# Altium schematic sheet NAUT_MFC_Front.SchDoc
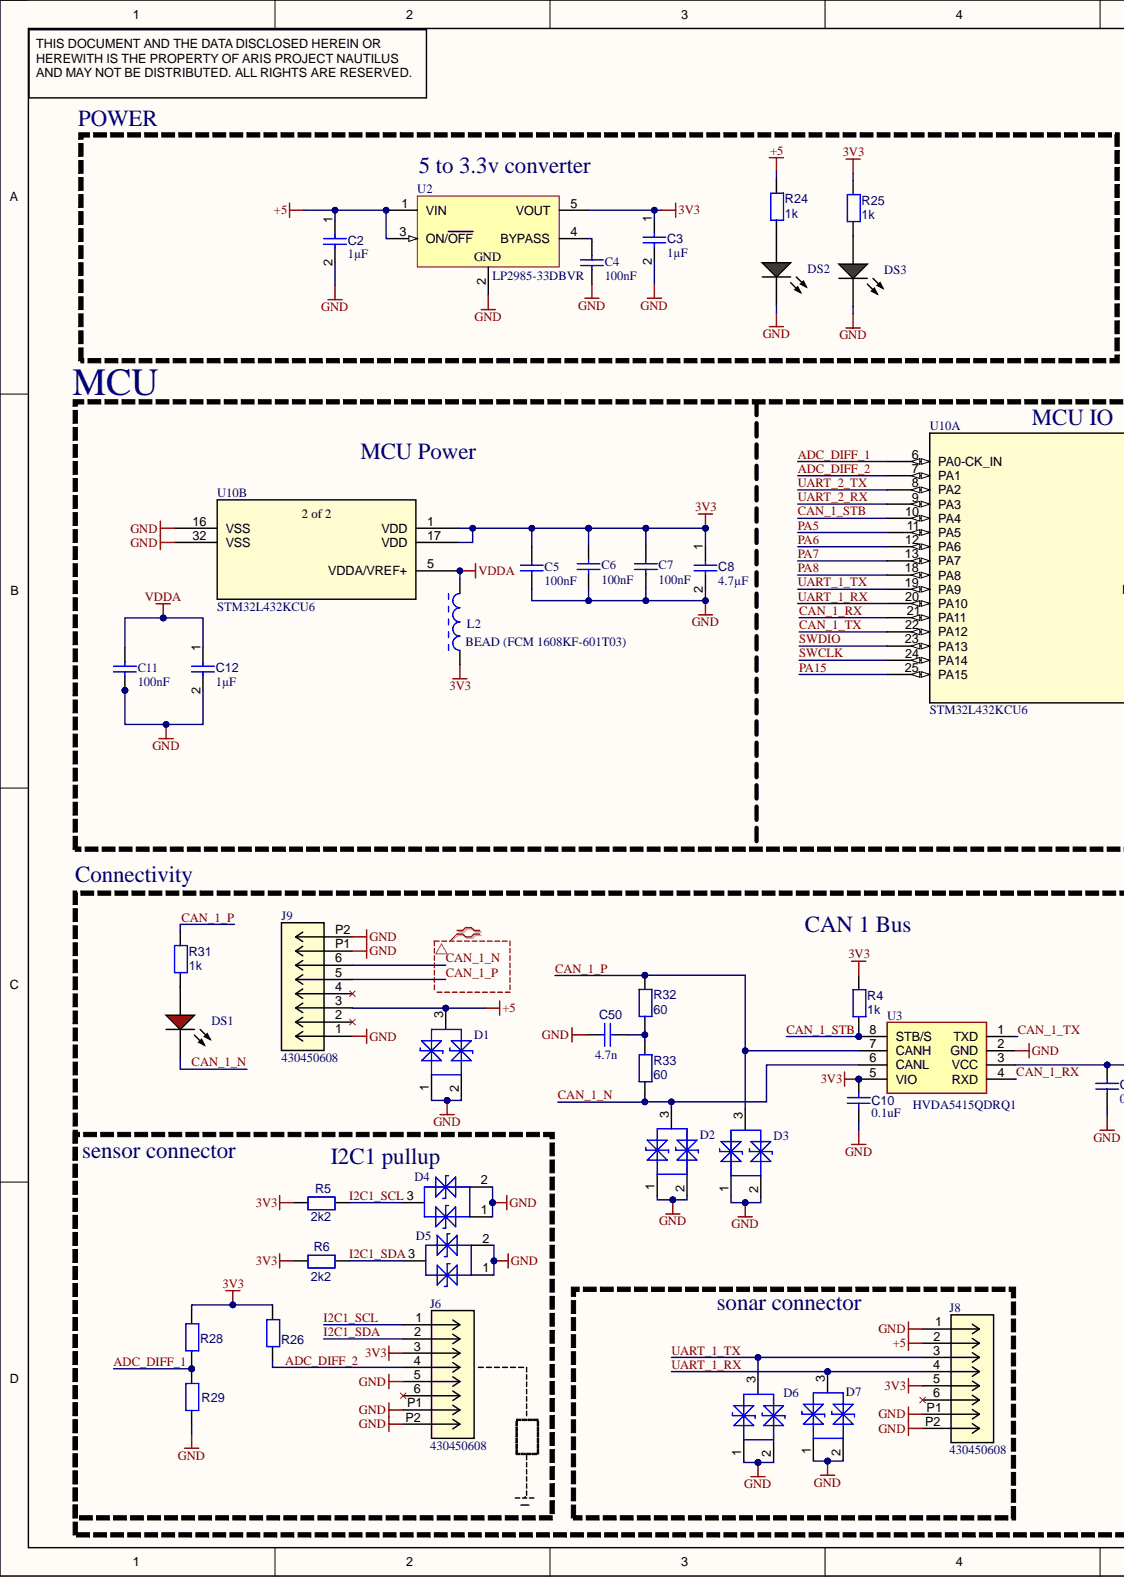
[diagram p0: NAUT_MFC_Front.SchDoc, left]
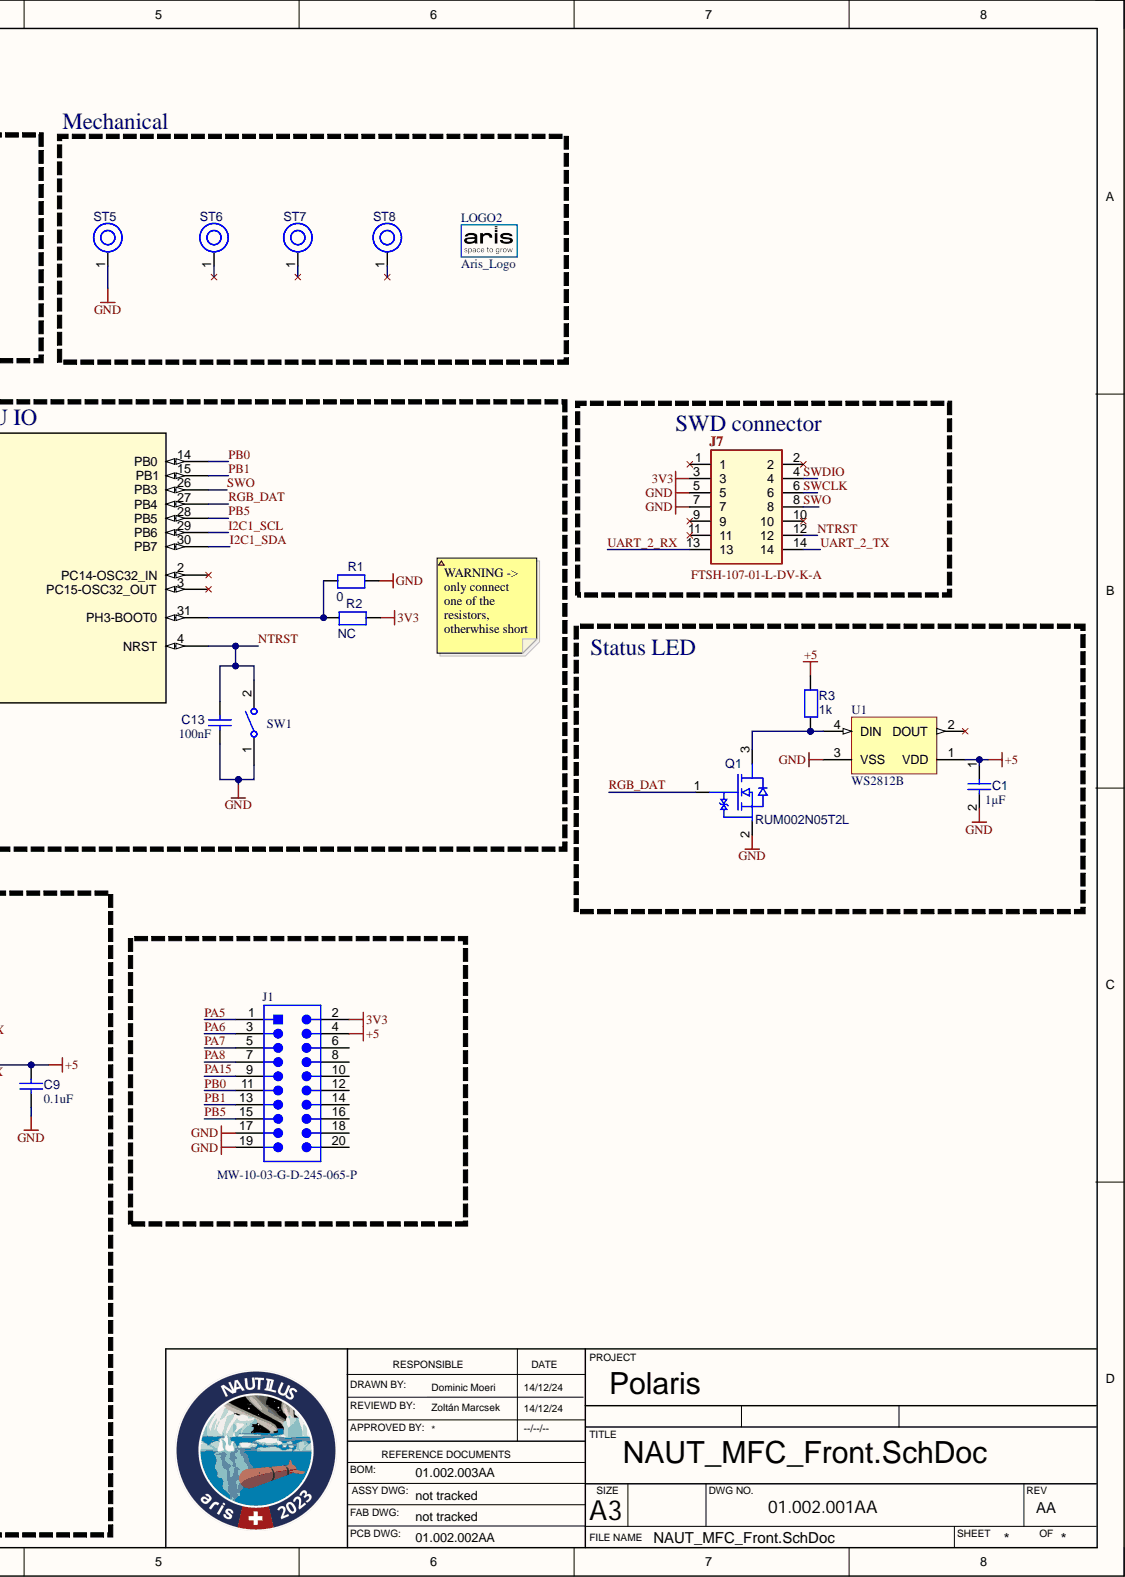
[diagram p1: NAUT_MFC_Front.SchDoc, right]

## Parts
C1  value 1µF  [CAPC1608X90X35ML15T15]  (hidden comment: Capacitor 1uF +/- 10% 16V 0603)
C2  value 1µF  [CAPC1608X90X35ML15T15]  (hidden comment: Capacitor 1uF +/- 10% 16V 0603)
C3  value 1µF  [CAPC1608X90X35ML15T15]  (hidden comment: Capacitor 1uF +/- 10% 16V 0603)
C4  value 100nF  [Capacitor 0402 ceramic]  (hidden comment: Capacitor)
C5  value 100nF  [Capacitor 0402 ceramic]  (hidden comment: Capacitor)
C6  value 100nF  [Capacitor 0402 ceramic]  (hidden comment: Capacitor)
C7  value 100nF  [Capacitor 0402 ceramic]  (hidden comment: Capacitor)
C8  value 4.7µF  [CAPC0603(1608)100_L]  (hidden comment: Capacitor 4.7µF +/-20% 10V 0603)
C9  value 0.1uF  [CAPC0603(1608)100_L]  (hidden comment: Capacitor 4.7µF +/-20% 10V 0603)
C10  value 0.1uF  [CAPC0603(1608)100_L]  (hidden comment: Capacitor 4.7µF +/-20% 10V 0603)
C11  value 100nF  [Capacitor 0402 ceramic]  (hidden comment: Capacitor)
C12  value 1µF  [CAPC0603(1608)100_L]  (hidden comment: Capacitor 1µF +/-20% 10V 0603)
C13  value 100nF  [CAPC0603(1608)100_L]  (hidden comment: Capacitor 100nF +/-20% 10V 0603)
C50  value 4.7n  [CAPC0603(1608)100_L]  (hidden comment: Capacitor 4.7µF +/-20% 10V 0603)
D1  ESD Protection diode  [SOT23]  (value not drawn)
D2  ESD Protection diode  [SOT23]  (value not drawn)
D3  ESD Protection diode  [SOT23]  (value not drawn)
D4  ESD Protection diode  [SOT23]  (value not drawn)
D5  ESD Protection diode  [SOT23]  (value not drawn)
D6  ESD Protection diode  [SOT23]  (value not drawn)
D7  ESD Protection diode  [SOT23]  (value not drawn)
DS1  LTST-C190KRKT  [LTST-C191KRKT_V]  (value not drawn)
DS2  LTST-C190GKT  [LTST-C190GKT_V]  (value not drawn)
DS3  LTST-C190GKT  [LTST-C190GKT_V]  (value not drawn)
J1  MW-10-03-G-D-245-065-P  [SMTC-MW-10-03-G-D-245-065-P_V]
J6  430450608  [Molex_microfit_CON_430450608]
J7  FTSH-107-01-L-DV-K-A  [FTSH-107-XX-YYY-DV-K-A]
J8  430450608  [Molex_microfit_CON_430450608]
J9  430450608  [Molex_microfit_CON_430450608]
L2  value BEAD (FCM 1608KF-601T03)  [INDC2013X105X50ML20T20]  (hidden comment: BLM21PG331SN1D)
LOGO2  Aris_Logo  [Aris_Logo]  (no BOM)
Q1  RUM002N05T2L  [ROHM-SOT723-3_V]
R1  0  [RESC0402(1005)_L]
R2  NC  [RESC0402(1005)_L]
R3  1k  [RESC0402(1005)_L]
R4  1k  [RESC0402(1005)_L]
R5  2k2  [RESC0402(1005)_L]
R6  2k2  [RESC0402(1005)_L]
R24  1k  [RESC0402(1005)_L]
R25  1k  [RESC0402(1005)_L]
R26  Resistor_0402_European  [RESC0402(1005)_L]  (value not drawn)
R28  Resistor_0402_European  [RESC0402(1005)_L]  (value not drawn)
R29  Resistor_0402_European  [RESC0402(1005)_L]  (value not drawn)
R31  1k  [RESC0402(1005)_L]
R32  60  [RESC0402(1005)_L]
R33  60  [RESC0402(1005)_L]
ST5  PTH-M2D5X5  [PTH-M2D5X5]  (value not drawn)  (no BOM)
ST6  PTH-M2D5X5  [PTH-M2D5X5]  (value not drawn)  (no BOM)
ST7  PTH-M2D5X5  [PTH-M2D5X5]  (value not drawn)  (no BOM)
ST8  PTH-M2D5X5  [PTH-M2D5X5]  (value not drawn)  (no BOM)
SW1  B3U-3000P  [OMRON-B3U-3000P_V]  (value not drawn)
U1  WS2812B  [ADAI-WS2812B_V]
U2  LP2985-33DBVR  [TI-DBV5_V]
U3  HVDA5415QDRQ1  [SOIC127P600X175-8N]
U10  STM32L432KCU6  [stm32l432kc]  (parts A,B)

## Nets
3V3: C3.1 C5.2 C6.2 C7.2 C8.1 C10.1 J1.2 J6.3 J7.3 J8.5 L2.1 R2.2 R4.2 R5.1 R6.1 R25.2 R26.2 R28.2 U2.5(VOUT) U3.5(VIO) U10.1(VDD) U10.17(VDD)
+5: C1.1 C2.1 C9.1 D1.3 J1.4 J8.2 J9.3 R3.2 R24.2 U1.1(VDD) U2.1(VIN) U2.3(ON/OFF) U3.3(VCC)
GND: C1.2 C2.2 C3.2 C4.1 C5.1 C6.1 C7.1 C8.2 C9.2 C10.2 C11.1 C12.2 C13.1 C50.2 D1.1 D1.2 D2.1 D2.2 D3.1 D3.2 D4.1 D4.2 D5.1 D5.2 D6.1 D6.2 D7.1 D7.2 DS2.2 DS3.2 J1.17 J1.19 J6.5 J6.P1 J6.P2 J7.5 J7.7 J8.1 J8.P1 J8.P2 J9.1 J9.P1 J9.P2 Q1.2 R1.2 R29.1 ST5.1 SW1.1 U1.3(VSS) U2.2 U3.2(GND) U10.16(VSS) U10.32(VSS)
VDDA: C11.2 C12.1 L2.2 U10.5(VDDA/VREF+)
ADC_DIFF_1: R28.1 R29.2 U10.6(PA0-CK_IN)
ADC_DIFF_2: J6.4 R26.1 U10.7(PA1)
CAN_1_N: D2.3 DS1.2 J9.6 R33.1 U3.6(CANL)
CAN_1_P: D3.3 J9.5 R31.2 R32.2 U3.7(CANH)
CAN_1_RX: U3.4(RXD) U10.21(PA11)
CAN_1_STB: R4.1 U3.8(STB/S) U10.10(PA4)
CAN_1_TX: U3.1(TXD) U10.22(PA12)
I2C1_SCL: D4.3 J6.1 R5.2 U10.29(PB6)
I2C1_SDA: D5.3 J6.2 R6.2 U10.30(PB7)
NTRST: C13.2 J7.12 SW1.2 U10.4(NRST)
PA5: J1.1 U10.11(PA5)
PA6: J1.3 U10.12(PA6)
PA7: J1.5 U10.13(PA7)
PA8: J1.7 U10.18(PA8)
PA15: J1.9 U10.25(PA15)
PB0: J1.11 U10.14(PB0)
PB1: J1.13 U10.15(PB1)
PB5: J1.15 U10.28(PB5)
RGB_DAT: Q1.1 U10.27(PB4)
SWCLK: J7.6 U10.24(PA14)
SWDIO: J7.4 U10.23(PA13)
SWO: J7.8 U10.26(PB3)
UART_1_RX: D7.3 J8.4 U10.20(PA10)
UART_1_TX: D6.3 J8.3 U10.19(PA9)
UART_2_RX: J7.13 U10.9(PA3)
UART_2_TX: J7.14 U10.8(PA2)
NetC4_2: C4.2 U2.4(BYPASS)
NetC50_1: C50.1 R32.1 R33.2
NetDS1_1: DS1.1 R31.1
NetDS2_1: DS2.1 R24.1
NetDS3_1: DS3.1 R25.1
NetQ1_3: Q1.3 R3.1 U1.4(DIN)
NetR1_1: R1.1 R2.1 U10.31(PH3-BOOT0)

Not connected: J1 6 8 10 12 14 16 18 20; J6 6; J7 1 2 9 10 11; J8 6; J9 2 4; ST6 1; ST7 1; ST8 1; U1 2(DOUT); U10 2(PC14-OSC32_IN) 3(PC15-OSC32_OUT)

## Library data of the parts
C1-C3 = CMP-001-00004-6: Chip Capacitor, 1 uF, +/- 10%, 16 V, 0603 (1608 Metric); Capacitor Type: Ceramic; Case/Package: 0603; Component Kind: Standard; Max Operating Temperature: 125°C; Min Operating Temperature: -55°C; Mounting Technology: SM; Pins: 2; RoHS Compliant: True; Tolerance: 10%; Voltage Rating: 16V; Library Name: Nautilus-Electronics-PCBs
C4-C7 C11 = MCD-00102: Capacitor, MLCC, 100nF, 16V, X7R, 10%, 0402, T=0,5mm; Manufacturer: YAGEO; Manufacturer_Part_Number: CC0402KRX7R7BB104; Database Table Name: MCD_PARTS$; Initial_Board_Reference: MB1262; Provided_By: Subcon; Component Kind: Standard; ComponentLink1Description: Yageo_Capacitor_X7R-series.pdf; ComponentLink1URL: http://tunmcdsvn.tun.st.com/Component_Datasheet_Library/Trunk/Capacitors/Yageo_Multilayer_Capacitor_X7R_series_100pF_to_22uF; ECCN_EU: n/a; ECCN_US: n/a; Internal_Part_Number: MCD-00102; Library Name: MCD_PARTS_database.DbLib; Package: C0402; Part_Type: Capacitor; Status: Released
C8 = CMP-001-00076-4: Chip Capacitor, 4.7µF +/-20%, 10V, 0603, Thickness 1 mm; Tolerance: 10%; Case/Package: 0603; Component Kind: Standard; Max Operating Temperature: 85°C; Min Operating Temperature: -55°C; Mounting Technology: SMT; Pins: 2; Voltage Rating: 10V; Library Name: Nautilus-Electronics-PCBs
C9 C10 C50 = CMP-001-00076-4: Tolerance: 10%; Case/Package: 0603; Component Kind: Standard; Max Operating Temperature: 85°C; Min Operating Temperature: -55°C; Mounting Technology: SMT; Pins: 2; Voltage Rating: 10V; Library Name: Nautilus-Electronics-PCBs
C12 = CMP-001-00076-4: Chip Capacitor, 1µF +/-20%, 10V, 0603, Thickness 1 mm; Tolerance: 10%; Case/Package: 0603; Component Kind: Standard; Max Operating Temperature: 85°C; Min Operating Temperature: -55°C; Mounting Technology: SMT; Pins: 2; Voltage Rating: 10V; Library Name: Nautilus-Electronics-PCBs
C13 = CMP-001-00076-4: Chip Capacitor, 100nF +/-20%, 10V, 0603, Thickness 1 mm; Tolerance: 10%; Case/Package: 0603; Component Kind: Standard; Max Operating Temperature: 85°C; Min Operating Temperature: -55°C; Mounting Technology: SMT; Pins: 2; Voltage Rating: 10V; Library Name: Nautilus-Electronics-PCBs
D1-D7 = NUP2105L: Component Kind: Standard; Library Name: NAUTILUS_COMPONENTS.SchLib
DS1 = CMP-007-00024-2: LED Uni-Color Red, 62.5 mW, 25 mA, -30 to 85 degC, 2-Pin SMD, RoHS, Tape and Reel; Manufacturer: Lite-On; Forward Current: 20mA; Forward Voltage: 2V; Lens Style: Diffused; Luminous Intensity: 54mcd; Power: 75mW; Viewing Angle: 130degrees; Case/Package: 0603; Colour: Red; Component Kind: Standard; Datasheet URL: http://optoelectronics.liteon.com/upload/download/DS-22-99-0151/S_110_LTST-C190KRKT.pdf; Datasheet Version: Rev. F, 05/2013; Illumination Colour: Red; Manufacturer URL: http://www.us.liteon.com/; Max Operating Temperature: 85°C; Min Operating Temperature: -55°C; Mounting Technology: SM; Nominal Vf: 2V; Package Description: 2-Pin Surface Mount Device, Body 1.6 x 0.8 mm; Package Quantity: 3000; Package Reference: C191KRKT; Packaging: TapeandReel; Pins: 2; RoHS Compliant: True; Library Name: Nautilus-Electronics-PCBs
DS2 DS3 = CMP-007-00022-2: LED Uni-Color Green, 100 mW, 30 mA, -55 to 85 degC, 2-Pin SMD, RoHS, Tape and Reel; Manufacturer: Lite-On; Forward Current: 20mA; Forward Voltage: 2.1V; Lens Style: Clear; Luminous Intensity: 6mcd; Power: 100mW; Viewing Angle: 130degrees; Case/Package: 0603; Colour: Green; Component Kind: Standard; Datasheet URL: http://datasheet.octopart.com/LTST-C190GKT-Lite-On-datasheet-158530.pdf; Datasheet Version: Dec-06; Illumination Colour: Green; Manufacturer URL: http://www.us.liteon.com/; Max Operating Temperature: 85°C; Min Operating Temperature: -55°C; Mounting Technology: SM; Nominal Vf: 2.1V; Package Description: 2-Pin Surface Mount Device, Body 1.6 x 0.8 mm; Package Quantity: 3000; Package Reference: C190GKT; Packaging: TapeandReel; Pins: 2; RoHS Compliant: True; Library Name: Nautilus-Electronics-PCBs
J1 = CMP-002-00046-2: Flexible Micro Board Stacker, Pitch 1 mm, 2 x 10 Position, Height 9.4 mm, -55 to 125 degC, RoHS, Tape and Reel; Manufacturer: Samtec; Connector Type: Header; Gender: Male; Case/Package: MW-10-03-G-D-245-065-P; Component Kind: Standard; Datasheet URL: http://suddendocs.samtec.com/prints/mw-xx-03-x-d-xxx-xxx-xx-mkt.pdf; Datasheet Version: 34759; Manufacturer URL: https://www.samtec.com/; Max Operating Temperature: 125°C; Min Operating Temperature: -55°C; Mounting Technology: SM; Package Description: Flexible Micro Board Stacker, Pitch 1 mm, 2 x 10 Position, Height 9.4 mm; Package Reference: MW-10-03-G-D-245-065-P; Packaging: TapeandReel; Pins: 20; RoHS Compliant: True; Library Name: Nautilus-Electronics-PCBs
J6 J8 J9 = Molex Microfit 430450608: No Description Available; Mfr_Name: Molex Connector Corporation; Component Kind: Standard; Manufacturer_Part_Number: 430450608; RefDes: RefDes; Type: DEV; Copyright: Copyright (C) 2023 Ultra Librarian. All rights reserved.; Library Name: NAUTILUS_COMPONENTS.SchLib
J7 = FTSH-107-01-L-DV-K-A: 14 position, High Reliability Header Strips, 0.050&quot; pitch, Dual-Row Vertical; Manufacturer_Name: SAMTEC; Component Kind: Standard; Description_: 14 position, High Reliability Header Strips, 0.050&quot; pitch, Dual-Row Vertical; Manufacturer_Part_Number: FTSH-107-01-L-DV-K-A; Mouser Part Number: 200-FTSH10701LDVKA; Mouser Price/Stock: https://www.mouser.co.uk/ProductDetail/Samtec/FTSH-107-01-L-DV-K-A?qs=PB6%2FjmICvI3xEHFou4PhNg%3D%3D; Supplier: http://suddendocs.samtec.com/prints/ftsh-1xx-xx-xxx-dv-xxx-xxx-mkt.pdf; Library Name: NAUTILUS_COMPONENTS.SchLib
L2 = CMP-006-00000-3: IND, Chip Ferrite Bead, 330 Ohm, +/- 25%, 1.5 A, -55 to 125 degC, 0805 (2012 Metric), Tape and Reel; Manufacturer: Murata; Current Rating: 1.5A; Impedance: 330Ohm; Resistance: 90mohm; Value: BEAD (FCM 1608KF-601T03); Case/Package: 0805; Component Kind: Standard; Core Material: Ferrite; Datasheet URL: http://psearch.en.murata.com/inductor/product/LQG15HS15NJ02_.pdf; Datasheet Version: Nov-11; Max Current Rating: 1.5A; Max Operating Temperature: 125°C; Min Operating Temperature: -55°C; Mounting Technology: SM; Package Description: 2-Pin Surface Mount Device, Body 2 x1.25 mm; Package Quantity: 4000; Package Reference: 0805; Packaging: TapeandReel; RoHS Compliant: True; Tolerance: 25%; Library Name: Nautilus-Electronics-PCBs
Q1 = CMP-013-00026-2: Nch Small Signal MOSFET, 50 V, 200 mA, 3-Pin SOT723, RoHS, Tape and Reel; Vgs(th) (Max) @ Id: 1V@1mA; Component Kind: Standard; Pins: 3; Library Name: Nautilus-Electronics-PCBs
R1-R6 R24 R25 R31-R33 = Resistor_0402_European: Resistor 0402 (1005 Metric); Case-EIA: 0402; Case-Metric: 1005; Component Kind: Standard; Technology: SMT; Library Name: NAUTILUS_COMPONENTS.SchLib
  R1: Value: 0
  R2: Value: NC
  R3 R4 R24 R25 R31: Value: 1k
  R5 R6: Value: 2k2
  R32 R33: Value: 60
R26 R28 R29 = Resistor_0402_European: Jumper 0402 (1005 Metric); Case-EIA: 0402; Case-Metric: 1005; Value: 0.00 Ohm; Component Kind: Standard; Technology: SMT; Library Name: NAUTILUS_COMPONENTS.SchLib
ST5-ST8 = CMP-00028-00004-2: M2 PTH (2.5mm), 5mm pad; Pins: 1; Library Name: Nautilus-Electronics-PCBs
SW1 = CMP-011-00005-2: Tactile Switch, SPST-NO, 0.05 A, 12 V, -25 to 70 degC, 2-Pin SMD, RoHS, Tape and Reel; Manufacturer: Omron Electronic Components; Contact Current Rating: 50mA; IP Rating: IP40; Max Contact Resistance: 100mohm; Max Voltage Rating (DC): 12V; Operating Force: 162gf; Throw Configuration: SPST; Case/Package: B3U-3000P; Component Kind: Standard; Contact Material: Silver; Current Rating: 50mA; Datasheet URL: https://www.omron.com/ecb/products/pdf/en-b3u.pdf; Datasheet Version: Jan-15; Manufacturer URL: http://www.omron.com/; Max Current Rating: 50mA; Max Operating Temperature: 70°C; Min Operating Temperature: -25°C; Mounting Technology: SM; Package Description: 2-Pin Surface Mount Device, Body 4 x 3.2 mm; Package Quantity: 4000; Package Reference: B3U-3000P; Packaging: TapeandReel; RoHS Compliant: True; Voltage Rating: 12V; Voltage Rating (DC): 12V; Library Name: Nautilus-Electronics-PCBs
U1 = CMP-007-00035-2: Intelligent Control LED Integrated Light Source, 3.5 to 5.3 V, -25 to 80 degC, 4-Pin SMD, RoHS, Bulk; Manufacturer: Adafruit Industries; Case/Package: WS2812B; Component Kind: Standard; Datasheet URL: https://cdn-shop.adafruit.com/datasheets/WS2812B.pdf; Datasheet Version: May-13; Manufacturer URL: https://www.adafruit.com/; Mounting Technology: SM; Package Description: 4-Pin Surface Mount Device, Body 5 x 5 mm, Pitch 3.3 mm; Package Reference: WS2812B; RoHS Compliant: False; Library Name: Nautilus-Electronics-PCBs
U2 = CMP-019-00026-3: Single Output Low Noise LDO, 150 mA, Fixed 3.3 V Output, 1.5% Tolerance, 2.2 to 16 V Input, Low IQ, 5-Pin SOT-23 (DBV), -40 to 125 degC, Green (RoHS & no Sb/Br), Tape and Reel; Manufacturer: Texas Instruments; Dropout Voltage: 3mV; Max Input Voltage: 16V; Max Ouput Current: 150mA; Min Input Voltage: 2.2V; Nominal Output Voltage: 3.3V; Outputs: 1; Package Version: 4073253-4/N, 12/2014; Case/Package: SOT-23-5; Component Kind: Standard; Datasheet URL: http://www.ti.com/lit/ds/symlink/lp2985.pdf; Datasheet Version: SLVS522O, 01/2015; Manufacturer URL: http://www.ti.com/; Max Operating Temperature: 125°C; Min Operating Temperature: -40°C; Mounting Technology: SM; Output Current: 150mA; Output Voltage: 3.3V; Package Description: 5-Pin Small Outline Transistor, Body 2.9 x 1.6 mm, Pitch 0.95 mm; Package Quantity: 3000; Package Reference: DBV5; Package URL: http://www.ti.com/lit/ml/mpds018l/mpds018l.pdf; Packaging: TapeandReel; Pins: 16; RoHS Compliant: True; Library Name: Nautilus-Electronics-PCBs
U3 = HVDA5415QDRQ1: Automotive Catalog 5-V CAN Transceiver; Manufacturer_Name: Texas Instruments; Geometry.Height: 1.75mm; Component Kind: Standard; Datasheet Link: http://www.ti.com/lit/gpn/sn65hvda541-5-q1; Manufacturer_Part_Number: HVDA5415QDRQ1; Mouser Part Number: 595-HVDA5415QDRQ1; Mouser Price/Stock: https://www.mouser.co.uk/ProductDetail/Texas-Instruments/HVDA5415QDRQ1?qs=1WmUhT%2FVMIOEOWq2u8yK4w%3D%3D; Library Name: NAUTILUS_COMPONENTS.SchLib
U10 = STM32L432KCU6: No Description Available; Mfr_Name: STMicroelectronics; Component Kind: Standard; Manufacturer_Part_Number: STM32L432KCU6; RefDes: RefDes; Supplier 1: Mouser; Supplier Part Number 1: 511-STM32L432KCU6; Type: DEV; Copyright: Copyright (C) 2023 Ultra Librarian. All rights reserved.; Library Name: NAUTILUS_COMPONENTS.SchLib
LOGO2 = Aris_Logo: Library Name: NAUTILUS_COMPONENTS.SchLib
